# Altium schematic sheet Schema2.SchDoc
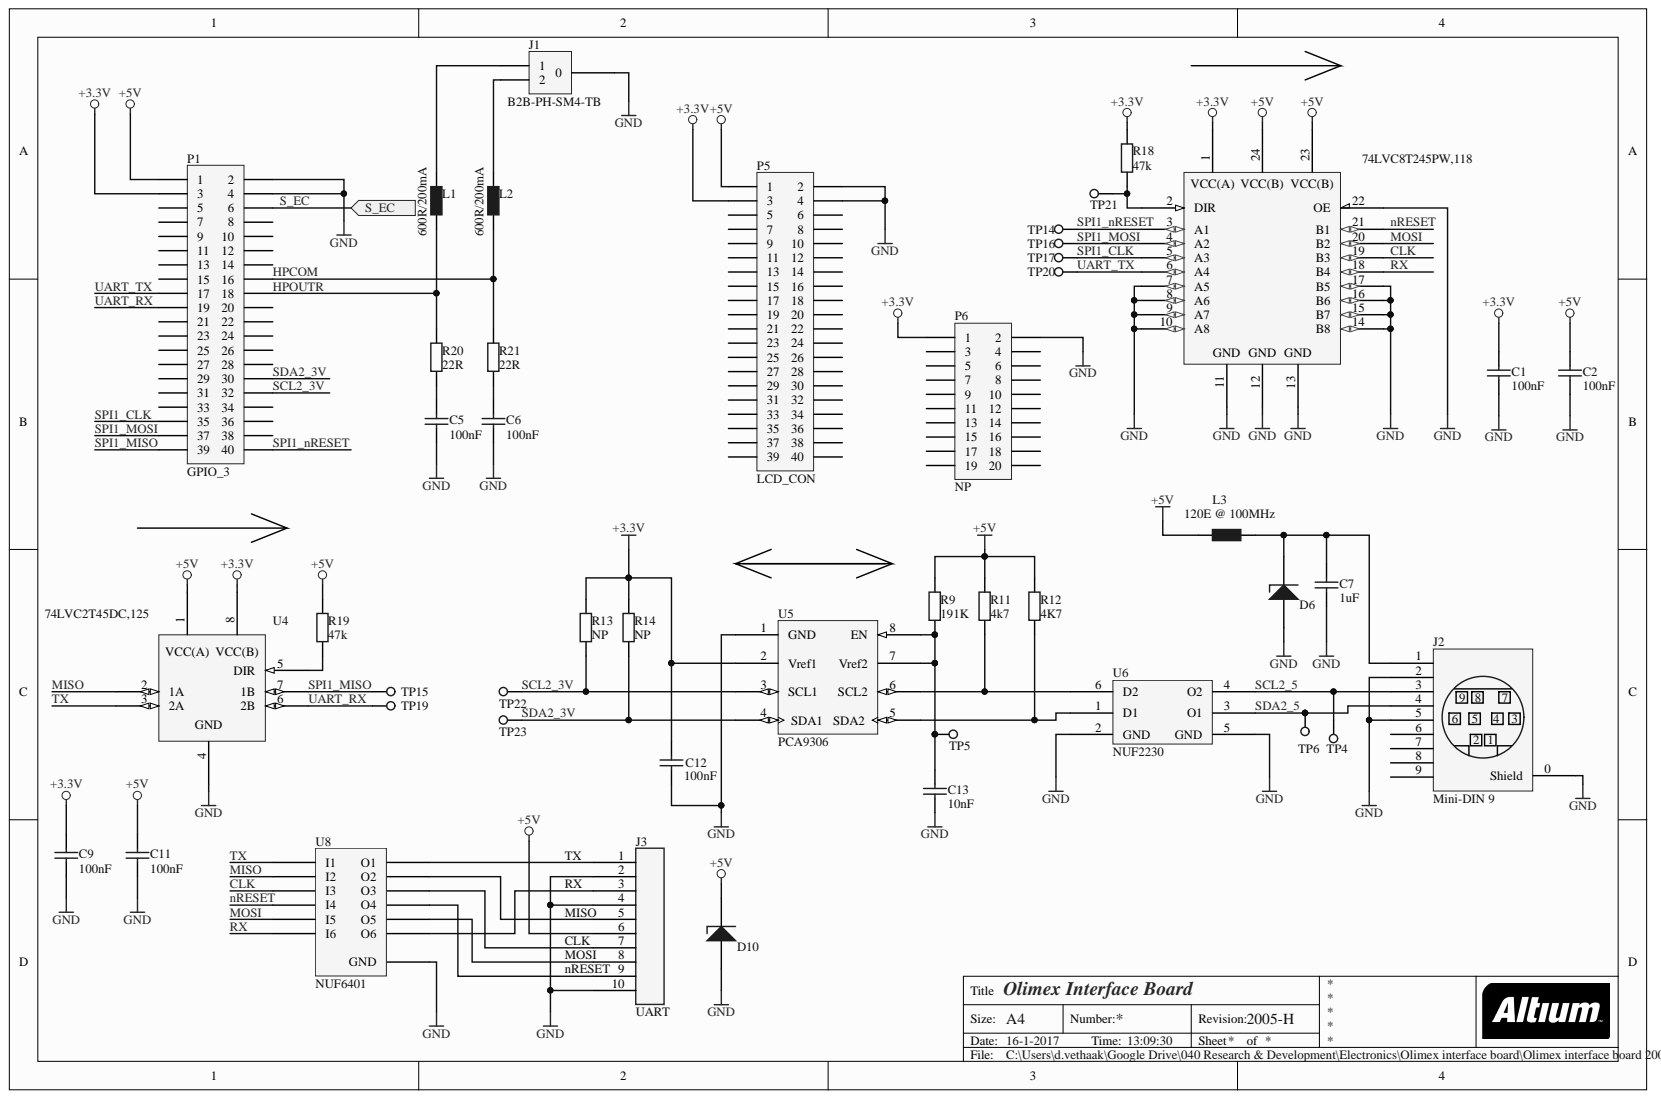
[diagram p0: Schema2.SchDoc]

## Parts
C1  100nF  [C0805 (2012)]
C2  100nF  [C0805 (2012)]
C5  100nF  [C0805 (2012)]
C6  100nF  [C0805 (2012)]
C7  1uF  [CAPC1608X09N]
C9  100nF  [C0805 (2012)]
C11  100nF  [C0805 (2012)]
C12  100nF  [C0805 (2012)]
C13  10nF  [C0805 (2012)]
D6  ESD9X5.0ST5G  [SODFL100X40-2N]  (value not drawn)
D10  ESD9X5.0ST5G  [SODFL100X40-2N]  (value not drawn)
J1  B2B-PH-SM4-TB  [B2B-PH-SM4-TB]
J2  Mini-DIN 9  [MD-90SM]
J3  UART  [BOXHEADER-10P-straight]
L1  600R/200mA  [R0805]
L2  600R/200mA  [R0805]
L3  120E @ 100MHz  [R0603]
P1  GPIO_3  [M50-3152042]
P5  LCD_CON  [M50-3152042]
P6  NP  [HARWIN M20]
R9  191K  [R0805 (2012)]
R11  4k7  [RESC1608X05N]
R12  4K7  [RESC1608X05N]
R13  NP  [R0805 (2012)]
R14  NP  [R0805 (2012)]
R18  47k  [RESC1608X05N]
R19  47k  [RESC1608X05N]
R20  22R  [RESC1608X05N]
R21  22R  [RESC1608X05N]
TP4  2mm  [TESTPOINT 2mm]  (value not drawn)  (no BOM)
TP5  2mm  [TESTPOINT 2mm]  (value not drawn)  (no BOM)
TP6  2mm  [TESTPOINT 2mm]  (value not drawn)  (no BOM)
TP14  2mm  [TESTPOINT 2mm]  (value not drawn)  (no BOM)
TP15  2mm  [TESTPOINT 2mm]  (value not drawn)  (no BOM)
TP16  2mm  [TESTPOINT 2mm]  (value not drawn)  (no BOM)
TP17  2mm  [TESTPOINT 2mm]  (value not drawn)  (no BOM)
TP19  2mm  [TESTPOINT 2mm]  (value not drawn)  (no BOM)
TP20  2mm  [TESTPOINT 2mm]  (value not drawn)  (no BOM)
TP21  2mm  [TESTPOINT 2mm]  (value not drawn)  (no BOM)
TP22  2mm  [TESTPOINT 2mm]  (value not drawn)  (no BOM)
TP23  2mm  [TESTPOINT 2mm]  (value not drawn)  (no BOM)
U3  74LVC8T245PW,118  [TSOP65P640X110-24N]
U4  74LVC2T45DC,125  [TSSOP50P310X100-8N]
U5  PCA9306  [IC SSOP-8]
U6  NUF2230  [SOTFL50P160X60-6N]
U8  NUF6401  [SON50P140X100_HS-13N]

## Ports
S_EC

## Nets
+3.3V: C1.1 C9.1 C12.2 P1.3 P5.3 P6.1 R13.2 R14.2 R18.1 U3.1 U4.8 U5.2(Vref1)
+5V: C2.1 C11.1 D10.1 J3.6 L3.1 P1.1 P5.1 R9.2 R11.2 R12.2 R19.1 U3.23 U3.24 U4.1
GND: C1.2 C2.2 C5.2 C6.2 C7.1 C9.2 C11.2 C12.1 C13.1 D6.2 D10.2 J1.0 J2.0(Shield) J2.2 J2.5 J3.2 J3.4 J3.10 P1.2 P1.4 P5.2 P5.4 P6.2 U3.7(A5) U3.8(A6) U3.9(A7) U3.10(A8) U3.11 U3.12 U3.13 U3.14(B8) U3.15(B7) U3.16(B6) U3.17(B5) U3.22(OE) U4.4 U5.1(GND) U6.2(GND) U6.5(GND) U8.13(GND)
CLK: U3.19(B3) U8.3(I3)
HPCOM: L2.1 P1.16 R21.2
HPOUTR: L1.1 P1.18 R20.2
MISO: U4.2(1A) U8.2(I2)
MOSI: U3.20(B2) U8.5(I5)
nRESET: U3.21(B1) U8.4(I4)
RX: U3.18(B4) U8.6(I6)
S_EC: P1.6 ; port S_EC
SCL2_3V: P1.32 R13.1 TP22.1 U5.3(SCL1)
SCL2_5: J2.3 TP4.1 U6.4(O2)
SDA2_3V: P1.30 R14.1 TP23.1 U5.4(SDA1)
SDA2_5: J2.4 TP6.1 U6.3(O1)
SPI1_CLK: P1.35 TP17.1 U3.5(A3)
SPI1_MISO: P1.39 TP15.1 U4.7(1B)
SPI1_MOSI: P1.37 TP16.1 U3.4(A2)
SPI1_nRESET: P1.40 TP14.1 U3.3(A1)
TX: U4.3(2A) U8.1(I1)
UART_RX: P1.19 TP19.1 U4.6(2B)
UART_TX: P1.17 TP20.1 U3.6(A4)
NetC5_1: C5.1 R20.1
NetC6_1: C6.1 R21.1
NetC7_2: C7.2 D6.1 J2.1 L3.2
NetC13_2: C13.2 R9.1 TP5.1 U5.7(Vref2) U5.8(EN)
NetJ1_1: J1.1 L1.2
NetJ1_2: J1.2 L2.2
NetJ3_1: J3.1 U8.12(O1)
NetJ3_3: J3.3 U8.7(O6)
NetJ3_5: J3.5 U8.11(O2)
NetJ3_7: J3.7 U8.10(O3)
NetJ3_8: J3.8 U8.8(O5)
NetJ3_9: J3.9 U8.9(O4)
NetR11_1: R11.1 U5.6(SCL2) U6.6(D2)
NetR12_1: R12.1 U5.5(SDA2) U6.1(D1)
NetR18_2: R18.2 TP21.1 U3.2(DIR)
NetR19_2: R19.2 U4.5(DIR)

Not connected: J2 6 7 8 9; P1 5 7 8 9 10 11 12 13 14 15 20 21 22 23 24 25 26 27 28 29 31 33 34 36 38; P5 5 6 7 8 9 10 11 12 13 14 15 16 17 18 19 20 21 22 23 24 25 26 27 28 29 30 31 32 33 34 35 36 37 38 39 40; P6 3 4 5 6 7 8 9 10 11 12 13 14 15 16 17 18 19 20

## Library data of the parts
C1 C2 C5 C6 C9 C11 C12 = Cap 100nF X7R 0805: Cap 100nF X7R 0805 10% 100V; Mfg: AVX; Mfg code: 08051C104K4T2A; Component Kind: Standard; Faber ItemCode: -; Max soldering temp: -; Remarks: -; SMD: Yes; Supplier: Farnell; Supplier code: 1833888; Value: 100nF; Library Name: Faber_SCH_lib.SchLib
C7 = 1uF/10V/0603: CAP CER 1UF 10V 10% X5R 0603; Manufacturer: Murata Electronics North America; Manufacturer Part Number: GRM188R61A105KA61D; Capacitance: 1.0µF; Applications: General Purpose; Category: Capacitors; Component Kind: Standard; ComponentLink1Description: http://psearch.murata.com/capacitor/product/GRM188R61A105KA61#.pdf; ComponentLink1URL: http://psearch.murata.com/capacitor/product/GRM188R61A105KA61#.pdf; Features: -; Height - Seated (Max): -; Lead Spacing: -; Lead Style: -; Mfg: Murata Electronics North America; Mfg code: GRM188R61A105KA61D; Mounting Type: Surface Mount, MLCC; Operating Temperature: -55°C ~ 85°C; Package / Case: 0603 (1608 Metric); Packaging: Digi-Reel®; Pricing 1: 1=0.15, 10=0.063, 50=0.0344, 100=0.0291, 250=0.02372, 500=0.02032, 1000=0.01583 (EUR); Ratings: -; RoHS: RoHS Compliant; Signal Integrity: Cap; Simulation: CAP; Size / Dimension: 0.063" L x 0.031" W (1.60mm x 0.80mm); Stock 1: 649155; Supplier 1: Digi-Key; Supplier Part Number 1: 490-1543-6-ND; Temperature Coefficient: X5R; Thickness (Max): 0.035" (0.90mm); Tolerance: ±10%; Value: 1uF; Voltage - Rated: 10V; Library Name: Marlin.SchLib
C13 = Cap 10nF X7R 0805: Cap 10nF X7R 0805 10% 100V; Mfg: AVX; Mfg code: 08051C103K4Z2A; Component Kind: Standard; Faber ItemCode: -; Max soldering temp: -; Remarks: -; SMD: Yes; Supplier: Farnell; Supplier code: 1833850; Value: 10nF; Library Name: Faber_SCH_lib.SchLib
D6 D10 = ESD9X5.0ST5G: TVS DIODE 5VWM 12.3VC SOD923; Capacitance @ Frequency: 65pF @ 1MHz; Current - Peak Pulse (10/1000µs): 8.7A (8/20µs); Mfg: ON Semiconductor; Power - Peak Pulse: 107W; Power Line Protection: No; Type: Zener; Unidirectional Channels: 1; Voltage - Breakdown (Min): 6.2V; Voltage - Clamping (Max) @ Ipp: 12.3V; Voltage - Reverse Standoff (Typ): 5V (Max); Applications: General Purpose; Bidirectional Channels: -; Category: Circuit Protection; Component Kind: Standard; ComponentLink1Description: http://www.onsemi.com/pub_link/Collateral/ESD9X3.3ST5G-D.PDF; ComponentLink1URL: http://www.onsemi.com/pub_link/Collateral/ESD9X3.3ST5G-D.PDF; Mfg code: ESD9X5.0ST5G; Mounting Type: Surface Mount; Operating Temperature: -55°C ~ 150°C (TJ); Package / Case: SOD-923; Packaging: Tape & Reel (TR); Pricing: 8000=0.02337, 16000=0.02032, 24000=0.01829, 56000=0.01626, 200000=0.01351 (EUR); RoHS: RoHS Compliant; Stock: 40000; Supplier 1: Digi-Key; Supplier Device Package: SOD-923; Supplier Part Number 1: ESD9X5.0ST5GOSTR-ND; Library Name: Olimex interface board.SCHLIB
J1 = Header 2_1: JST B2B-PH-SM4-TB; Component Kind: Standard; Manufacturer: JST; Manufacturer Part Number: B2B-PH-SM4-TB; Mfg: JST; Mfg code: B2B-PH-SM4-TB; Signal Integrity: Connector; Library Name: Olimex interface board.SCHLIB
J2 = Mini-DIN 9: Connector Type: Receptacle, Female Sockets; Current Rating: 1A, 2A; Mfg: CUI Inc.; Number of Positions: 9; Voltage - Rated: 100VAC, 12VDC; Category: Connectors, Interconnects; Component Kind: Standard; ComponentLink1Description: http://www.cui.com/product/resource/digikeypdf/md-xxsm_series.pdf; ComponentLink1URL: http://www.cui.com/product/resource/digikeypdf/md-xxsm_series.pdf; Contact Finish: Silver; Contact Finish Thickness: -; Fastening Type: Push-Pull; Features: Shielded; Ingress Protection: -; Mfg code: MD-90SM; Mounting Type: Through Hole, Right Angle; Operating Temperature: -40°C ~ 85°C; Orientation: Keyed; Packaging: Bulk; Pricing: 1=1.81, 10=1.505, 100=1.1146, 500=0.89166, 1000=0.80808 (EUR); RoHS: RoHS Compliant; Shell Material, Finish: Polybutylene Terephthalate (PBT); Shell Size, MIL: -; Shell Size - Insert: Mini DIN; Stock: 370; Supplier 1: Digi-Key; Supplier Part Number 1: CP-2290-ND; Termination: Solder; Library Name: Olimex interface board.SCHLIB
J3 = Library_CON-0019: RO CONN PR HDR BOX 10p; Database Table Name: Connectoren$; Mfg: Wurth; Mfg code: 61201021621; Component Kind: Standard; Supplier: Farnell; Supplier code: 1642019; Library Name: Database_Faber.DbLib
L1 L2 = (no symbol name): Mfg: Fair-rite; Mfg code: 2508056017Y2; Component Kind: Standard; Farnell code: 1838838RL; Supplier: Farnell; Supplier code: 1838838RL; Library Name: Ultimaker.SCHLIB
L3 = (no symbol name): FERRIET BLM18PG121SN1D 0603 MURATA; Info: Resistor; Component Kind: Standard; Faber ItemCode: -; ID: -; Mfg: Murata; Mfg code: BLM18PG121SN1D; Value: 120E @ 100MHz; Library Name: Ultimaker.SCHLIB
P1 P5 = MHDR2X20: HARWIN - M50-3152042 - SOCKET, DUAL ENTRY, SMT, 40WAY; Component Kind: Standard; Farnell code: 1189109; LatestRevisionDate: 17-Jul-2002; LatestRevisionNote: Re-released for DXP Platform.; Mfg: Harwin; Mfg code: M50-3152042; Supplier: Farnell; Supplier code: 1189109; Library Name: Olimex interface board_1.SCHLIB; Publisher: Altium Limited
P6 = MHDR2X10: HARWIN M20; Component Kind: Standard; LatestRevisionDate: 17-Jul-2002; LatestRevisionNote: Re-released for DXP Platform.; Library Name: Miscellaneous Connectors.IntLib; Signal Integrity: Connector; Publisher: Altium Limited
R9 = Res 191K 0805: Res 191K 0805 1% 125mW; Mfg: VISHAY DRALORIC; Mfg code: CRCW0805191KFKEA; Component Kind: Standard; Faber ItemCode: -; Max soldering temp: -; Remarks: -; SMD: Yes; Supplier: Farnell; Supplier code: 2139049; Value: 191K; Library Name: Faber_SCH_lib.SchLib
R11 = Res 4.7K 0805: =description; Manufacturer: Vishay Dale; Manufacturer Part Number: CRCW06034K70FKEA; Power (Watts): 0.1W, 1/10W; Resistance (Ohms): 4.7k; Temperature Coefficient: ±100ppm/°C; Tolerance: ±1%; Category: Resistors; Component Kind: Standard; ComponentLink1Description: http://www.vishay.com/docs/20035/dcrcwe3.pdf; ComponentLink1URL: http://www.vishay.com/docs/20035/dcrcwe3.pdf; Composition: Thick Film; Failure Rate: -; Features: Automotive AEC-Q200; Height - Seated (Max): 0.020" (0.50mm); Number of Terminations: 2; Operating Temperature: -55°C ~ 155°C; Package / Case: 0603 (1608 Metric); Packaging: Digi-Reel®; Part Status: Active; Pricing 1: 1=0.1, 10=0.029, 25=0.0212, 50=0.0162, 100=0.0118, 250=0.00904, 500=0.00722, 1000=0.00532 (EUR); RoHS: RoHS Compliant; Size / Dimension: 0.063" L x 0.033" W (1.60mm x 0.85mm); Stock 1: 396545; Supplier 1: Digi-Key; Supplier Device Package: 0603; Supplier Part Number 1: 541-4.70KHDKR-ND; Library Name: Faber_SCH_lib.SchLib
R12 = Res 4.7K 0805: =Description; Manufacturer: Vishay Dale; Manufacturer Part Number: CRCW06034K70FKEA; Power (Watts): 0.1W, 1/10W; Resistance (Ohms): 4.7k; Temperature Coefficient: ±100ppm/°C; Tolerance: ±1%; Category: Resistors; Component Kind: Standard; ComponentLink1Description: http://www.vishay.com/docs/20035/dcrcwe3.pdf; ComponentLink1URL: http://www.vishay.com/docs/20035/dcrcwe3.pdf; Composition: Thick Film; Failure Rate: -; Features: Automotive AEC-Q200; Height - Seated (Max): 0.020" (0.50mm); Number of Terminations: 2; Operating Temperature: -55°C ~ 155°C; Package / Case: 0603 (1608 Metric); Packaging: Digi-Reel®; Part Status: Active; Pricing 1: 1=0.1, 10=0.029, 25=0.0212, 50=0.0162, 100=0.0118, 250=0.00904, 500=0.00722, 1000=0.00532 (EUR); RoHS: RoHS Compliant; Size / Dimension: 0.063" L x 0.033" W (1.60mm x 0.85mm); Stock 1: 396545; Supplier 1: Digi-Key; Supplier Device Package: 0603; Supplier Part Number 1: 541-4.70KHDKR-ND; Library Name: Faber_SCH_lib.SchLib
R13 R14 = Res 4.7K 0805: Res 4.7K 0805 1% 100mW; Mfg: MULTICOMP; Mfg code: MC 0.1W 0805 1% 4K7; Component Kind: Standard; Faber ItemCode: -; Max soldering temp: -; Remarks: -; SMD: Yes; Supplier: Farnell; Supplier code: 9333266; Value: 4.7K; Library Name: Faber_SCH_lib.SchLib
R18 R19 = 47k/0603: RES 47.0K OHM 1/10W 1% 0603 SMD; Manufacturer: Vishay Dale; Manufacturer Part Number: CRCW060347K0FKEA; Power (Watts): 0.1W, 1/10W; Temperature Coefficient: ±100ppm/°C; Category: Resistors; Component Kind: Standard; ComponentLink1Description: http://www.vishay.com/doc?20035; ComponentLink1URL: http://www.vishay.com/doc?20035; Composition: Thick Film; Features: Automotive AEC-Q200 Qualified; Height: 0.020" (0.50mm); Mfg: Vishay Dale; Mfg code: CRCW060347K0FKEA; Number of Terminations: 2; Package / Case: 0603 (1608 Metric); Packaging: Digi-Reel®; Pricing 1: 10=0.069, 50=0.037, 200=0.02135, 1000=0.01454 (EUR); Resistance (Ohms): 47k; RoHS: RoHS Compliant; Signal Integrity: Res; Simulation: RESISTOR; Size / Dimension: 0.061" L x 0.033" W (1.55mm x 0.85mm); Stock 1: 78114; Supplier: Farnell; Supplier 1: Digi-Key; Supplier code: 2122577; Supplier Device Package: 0603 (1608 Metric); Supplier Part Number 1: 541-47.0KHDKR-ND; Tolerance: ±1%; Library Name: Marlin.SchLib
R20 R21 = 2.2k/0603: RES 22R OHM 63mW 1% 0603 SMD; Mfg: MULTICOMP; Mfg code: MC0063W0603122R; Component Kind: Standard; Signal Integrity: Res; Simulation: RESISTOR; Supplier: Farnell; Supplier code: 2130723
TP4-TP6 TP14-TP17 TP19-TP23 = (no symbol name): Testpoint, 2mm, round; Remarks: Not a component; Faber ItemCode: -; Max soldering temp: -; Mfg: -; Mfg code: -; Supplier: -; Supplier code: -; Value: -; Library Name: Faber_SCH_lib.SchLib
U3 = 74LVC8T245PW,118: 8-bit translaing transceiver; Mfg: NXP; Component Kind: Standard; Mfg code: 74LVC8T245PW,118; Supplier: Farnell; Supplier code: 2444917; Library Name: Olimex interface board.SchLib
U4 = 74LVC2T45DC,125: 2-bit translaing transceiver; Mfg: NXP; Component Kind: Standard; Mfg code: 74LVC2T45DC,125; Supplier: Farnell; Supplier code: 2444915; Library Name: Olimex interface board.SchLib
U5 = IC PCA9306: IC PCA9306 Voltage Level translator; Mfg: TEXAS INSTRUMENTS; Mfg code: PCA9306DCTR; Component Kind: Standard; Faber ItemCode: -; Max soldering temp: -; Remarks: -; SMD: Yes; Supplier: Farnell; Supplier code: 1470936; Value: -; Library Name: Faber_SCH_lib.SchLib
U6 = NUF2230: Attenuation Value: 30dB @ 800MHz ~ 900MHz; Center / Cutoff Frequency: 125MHz (Cutoff); ESD Protection: Yes; Filter Order: 2nd; Mfg: ON Semiconductor; Mfg code: NUF2230XV6T1G; Number of Channels: 2; Resistance - Channel (Ohms): 100; Values: R = 100 Ohms, C = 16pF; Applications: Data Lines for Mobile Devices; Category: Filters; Component Kind: Standard; ComponentLink1Description: http://www.onsemi.com/pub_link/Collateral/NUF2230XV6-D.PDF; ComponentLink1URL: http://www.onsemi.com/pub_link/Collateral/NUF2230XV6-D.PDF; Current: -; Height: 0.024" (0.60mm); Operating Temperature: -40°C ~ 85°C; Package / Case: SOT-563, SOT-666; Packaging: Cut Tape (CT); Pricing: 1=0.27, 10=0.252, 25=0.2404, 50=0.2326, 100=0.2248, 250=0.21316, 500=0.17828, 1000=0.13836 (EUR); RoHS: RoHS Compliant; Size / Dimension: 0.063" L x 0.047" W (1.60mm x 1.20mm); Stock: 3048; Supplier 1: Digi-Key; Supplier Part Number 1: NUF2230XV6T1GOSCT-ND; Technology: RC (Pi); Type: Low Pass; Library Name: printehead.SCHLIB
U8 = NUF6401: Attenuation Value: 30dB @ 800MHz ~ 3GHz; Center / Cutoff Frequency: 110MHz (Cutoff); ESD Protection: Yes; Filter Order: 2nd; Mfg: ON Semiconductor; Mfg code: NUF6401MNT1G; Number of Channels: 6; Resistance - Channel (Ohms): 100; Values: R = 100 Ohms, C = 17pF; Applications: Data Lines for Mobile Devices; Category: Filters; Component Kind: Standard; ComponentLink1Description: http://www.onsemi.cn/pub_link/Collateral/NUF6401-D.PDF; ComponentLink1URL: http://www.onsemi.cn/pub_link/Collateral/NUF6401-D.PDF; Current: -; Height: 0.039" (1.00mm); Operating Temperature: -40°C ~ 85°C; Package / Case: 12-VFDFN Exposed Pad; Packaging: Tape & Reel (TR); Pricing: 3000=0.13931, 6000=0.13111, 15000=0.12292, 30000=0.11472 (EUR); RoHS: RoHS Compliant; Size / Dimension: 0.118" L x 0.053" W (3.00mm x 1.35mm); Stock: 6000; Supplier 1: Digi-Key; Supplier Part Number 1: NUF6401MNT1GOSTR-ND; Technology: RC (Pi); Type: Low Pass; Library Name: UltiController.SCHLIB
